# Altium schematic sheet Power.SchDoc
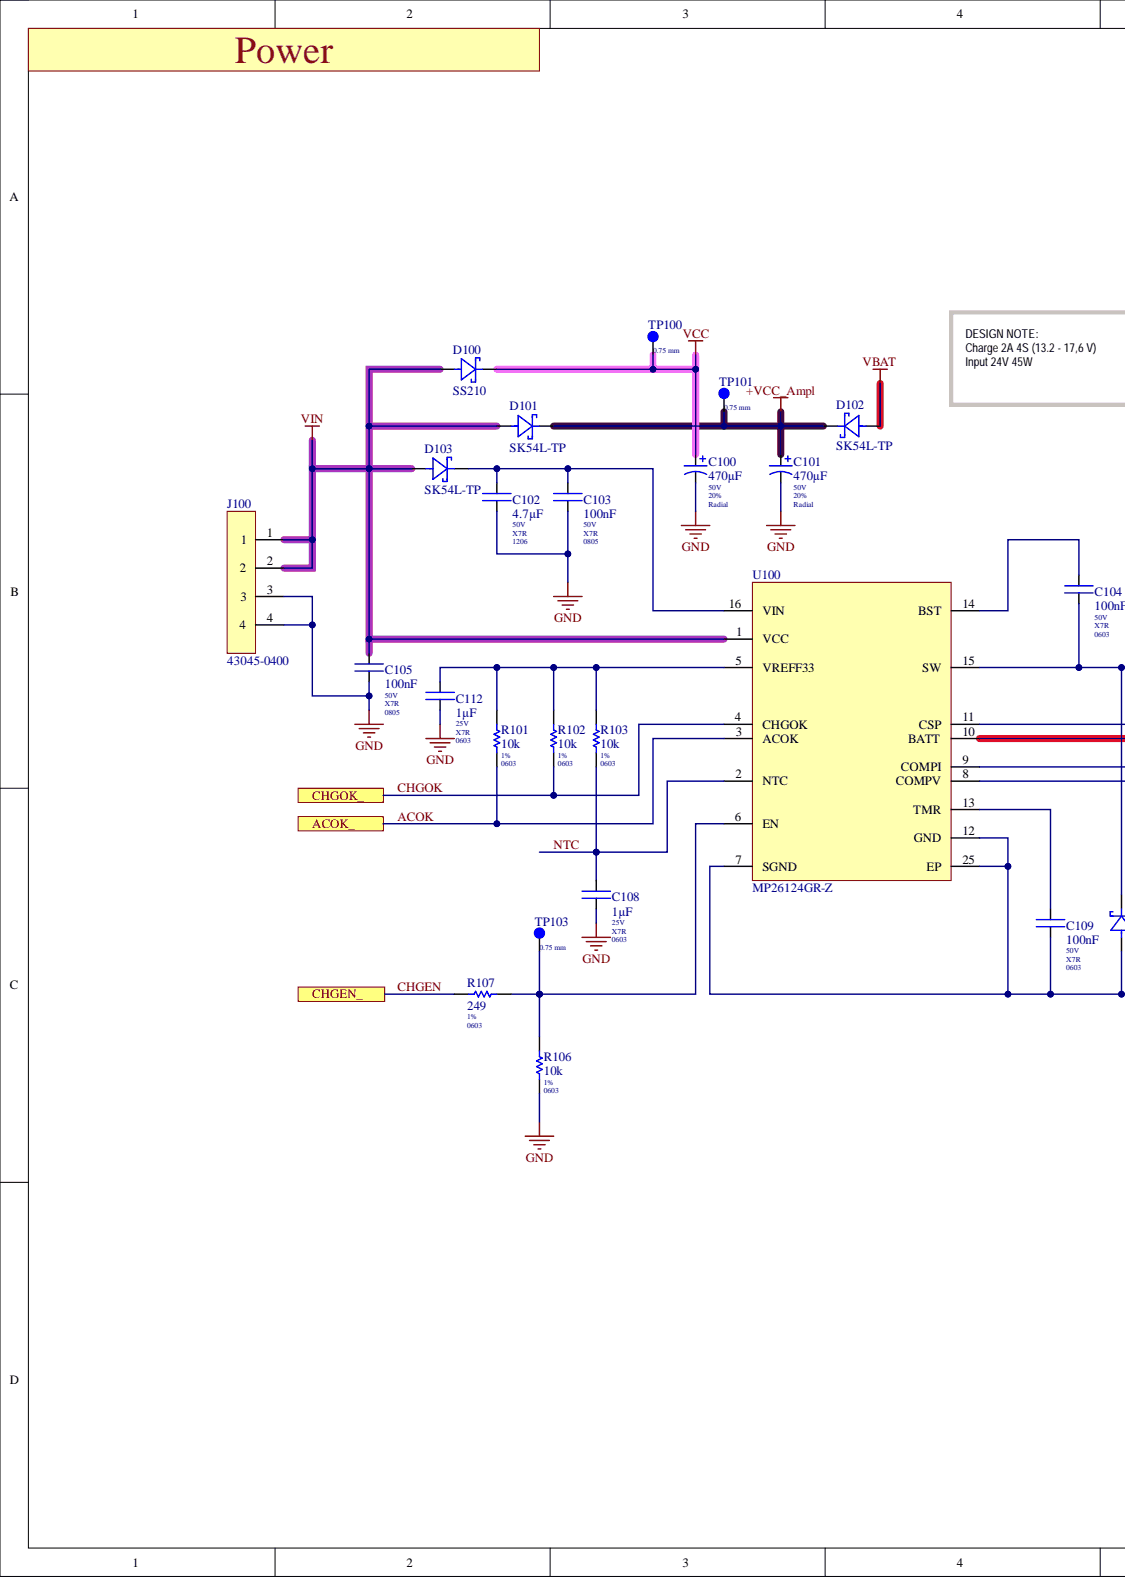
[diagram p0: Power.SchDoc, left]
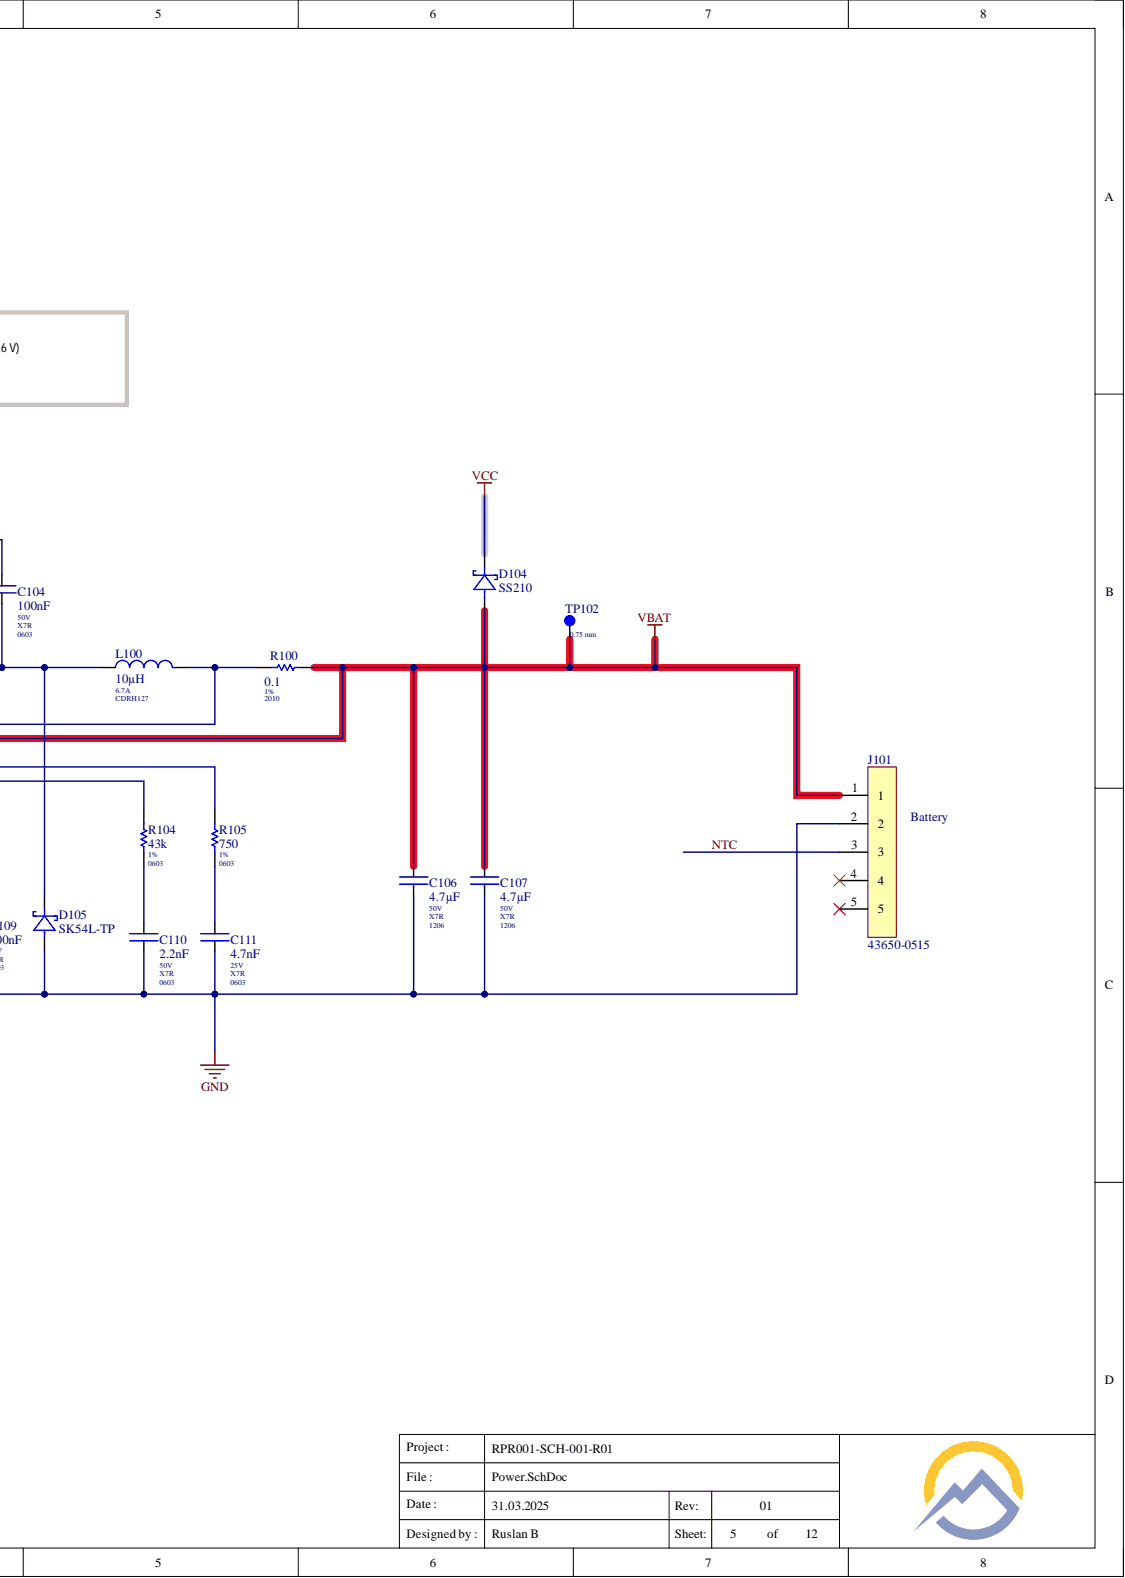
[diagram p1: Power.SchDoc, right]

## Parts
C100  value 470µF  Case/Package: Radial  Tolerance: 20%  Voltage Rating: 50V  [EMVA500ARA471MKG5S]  (hidden comment: EMVA500ARA471MKG5S)
C101  value 470µF  Case/Package: Radial  Tolerance: 20%  Voltage Rating: 50V  [EMVA500ARA471MKG5S]  (hidden comment: EMVA500ARA471MKG5S)
C102  value 4.7µF  Case/Package: 1206  Dielectric: X7R  Voltage Rating: 50V  [B_Cap_1206_KEM]  (hidden comment: GRM31CR71H475KA12L)
C103  value 100nF  Case/Package: 0805  Dielectric: X7R  Voltage Rating: 50V  [B_Cap_0805_KEM]  (hidden comment: CC0805KRX7R9BB104)
C104  value 100nF  Case/Package: 0603  Dielectric: X7R  Voltage Rating: 50V  [B_Cap_0603_KEM]  (hidden comment: GCM188R71H104KA57D)
C105  value 100nF  Case/Package: 0805  Dielectric: X7R  Voltage Rating: 50V  [B_Cap_0805_KEM]  (hidden comment: CC0805KRX7R9BB104)
C106  value 4.7µF  Case/Package: 1206  Dielectric: X7R  Voltage Rating: 50V  [B_Cap_1206_KEM]  (hidden comment: GRM31CR71H475KA12L)
C107  value 4.7µF  Case/Package: 1206  Dielectric: X7R  Voltage Rating: 50V  [B_Cap_1206_KEM]  (hidden comment: GRM31CR71H475KA12L)
C108  value 1µF  Case/Package: 0603  Dielectric: X7R  Voltage Rating: 25V  [B_Cap_0603_KEM]  (hidden comment: CL10B105KA8NNNC)
C109  value 100nF  Case/Package: 0603  Dielectric: X7R  Voltage Rating: 50V  [B_Cap_0603_KEM]  (hidden comment: GCM188R71H104KA57D)
C110  value 2.2nF  Case/Package: 0603  Dielectric: X7R  Voltage Rating: 50V  [B_Cap_0603_KEM]  (hidden comment: CC0603KRX7R9BB222)
C111  value 4.7nF  Case/Package: 0603  Dielectric: X7R  Voltage Rating: 25V  [B_Cap_0603_KEM]  (hidden comment: AC0603KRX7R8BB472)
C112  value 1µF  Dielectric: X7R  Voltage Rating: 25V  [B_Cap_0603_KEM]  (hidden comment: CL10B105KA8NNNC)
D100  SS210  [DO-214AA, SMB]
D101  SK54L-TP  [DO-214AB, SMC]
D102  SK54L-TP  [DO-214AB, SMC]
D103  SK54L-TP  [DO-214AB, SMC]
D104  SS210  [DO-214AA, SMB]
D105  SK54L-TP  [DO-214AB, SMC]
J100  43045-0400  [43045-0400-Footprint-1]
J101  43650-0515  [FP-43650-0515-MFG]
L100  value 10µH  Case/Package: CDRH127  Current Rating: 6.7A  [DR127]  (hidden comment: CDRH127/LDNP-100MC)
R100  value 0.1  Case/Package: 2010  Tolerance: 1%  [Res_2010_YAG_R]  (hidden comment: PE2010FKE070R1L)
R101  value 10k  Case/Package: 0603  Tolerance: 1%  [Res_0603_YAG]  (hidden comment: RC0603FR-0710KL)
R102  value 10k  Case/Package: 0603  Tolerance: 1%  [Res_0603_YAG]  (hidden comment: RC0603FR-0710KL)
R103  value 10k  Case/Package: 0603  Tolerance: 1%  [Res_0603_YAG]  (hidden comment: RC0603FR-0710KL)
R104  value 43k  Case/Package: 0603  Tolerance: 1%  [Res_0603_YAG]  (hidden comment: RC0603FR-0743KL)
R105  value 750  Case/Package: 0603  Tolerance: 1%  [Res_0603_YAG]  (hidden comment: RC0603FR-07750RL)
R106  value 10k  Case/Package: 0603  Tolerance: 1%  [Res_0603_YAG]  (hidden comment: RC0603FR-0710KL)
R107  value 249  Case/Package: 0603  Tolerance: 1%  [Res_0603_YAG]  (hidden comment: RC0603FR-07249RL)
TP100  0.75 mm  [0.75mm]  (no BOM)
TP101  0.75 mm  [0.75mm]  (no BOM)
TP102  0.75 mm  [0.75mm]  (no BOM)
TP103  0.75 mm  [0.75mm]  (no BOM)
U100  MP26124GR-Z  [16-VQFN]

## Ports
ACOK_, CHGEN_, CHGOK_

## Nets
+VCC_Ampl: C101.1 D101.1 D102.1 TP101.1
GND: C100.2 C101.2 C102.1 C103.1 C105.1 C106.1 C107.1 C108.1 C109.1 C110.1 C111.1 C112.1 D105.2 J100.3 J100.4 J101.2 R106.1 U100.7(SGND) U100.12(GND) U100.25(EP)
VBAT: C106.2 C107.2 D102.2 D104.2 J101.1 R100.2 TP102.1 U100.10(BATT)
VCC: C100.1 D100.1 D104.1 TP100.1
VIN: C105.2 D100.2 D101.2 D103.2 J100.1 J100.2 U100.1(VCC)
ACOK: R101.1 U100.3(ACOK) ; port ACOK_
CHGEN: R107.1 ; port CHGEN_
CHGOK: R102.1 U100.4(CHGOK) ; port CHGOK_
NTC: C108.2 J101.3 R103.1 U100.2(NTC)
NetC102_2: C102.2 C103.2 D103.1 U100.16(VIN)
NetC104_1: C104.1 D105.1 L100.1 U100.15(SW)
NetC104_2: C104.2 U100.14(BST)
NetC109_2: C109.2 U100.13(TMR)
NetC110_2: C110.2 R104.1
NetC111_2: C111.2 R105.2
NetC112_2: C112.2 R101.2 R102.2 R103.2 U100.5(VREFF33)
NetL100_2: L100.2 R100.1 U100.11(CSP)
NetR104_2: R104.2 U100.8(COMPV)
NetR105_1: R105.1 U100.9(COMPI)
NetR106_2: R106.2 R107.2 TP103.1 U100.6(EN)

Not connected: J101 4 5

## Library data of the parts
C100 C101 = CMP-CAP-ALU-00015-7: CAP ALUM 470UF 20% 50V SMD; Manufacturer 1: United Chemi-Con; Ripple Current: 740mA; Component Kind: Standard; ComponentLink1Description: Datasheet; ComponentLink1URL: https://www.chemi-con.co.jp/products/relatedfiles/capacitor/catalog/MVARA-e.PDF; Library Name: <Company> Server; Manufacturer Part Number 1: EMVA500ARA471MKG5S; Mount: Surface Mount
C102 C106 C107 = CMP-CAP-CER-00205-11: CAP CER 4.7UF 50V X7R 1206; Manufacturer 1: Murata; Tolerance: 10%; Component Kind: Standard; ComponentLink1Description: Datasheet; ComponentLink1URL: https://www.murata.com/~/media/webrenewal/support/library/catalog/products/capacitor/mlcc/c02e.ashx?la=en-us; Library Name: <Company> Server; Manufacturer Part Number 1: GRM31CR71H475KA12L
C103 C105 = CMP-CAP-CER-00029-14: Multilayer Ceramic Capacitor, 0.1 uF, 50 V, ï¿½ 10%, X7R, 0805 [2012 Metric]; Manufacturer 1: YAGEO; Component Kind: Standard; Library Name: <Company> Server; Manufacturer Part Number 1: CC0805KRX7R9BB104; Tolerance: 10%
C104 C109 = CMP-CAP-CER-00027-13: CAP CER 0.1UF 50V X7R 0603; Manufacturer 1: Murata; Tolerance: ±10%; Component Kind: Standard; ComponentLink1Description: Datasheet; ComponentLink1URL: https://search.murata.co.jp/Ceramy/image/img/A01X/G101/ENG/GCM188R71H104KA57-01.pdf; Library Name: <Company> Server; Manufacturer Part Number 1: GCM188R71H104KA57D
C108 C112 = CMP-CAP-CER-00132-13: CL10B105KA8NNNC Samsung Ceramic Capacitor MLCC 1uF10% 25V X7R SMD 0603 (1608 Metric) RoHS; Manufacturer 1: Samsung Electro-Mechanics; Tolerance: 10%; Component Kind: Standard; Library Name: <Company> Server; Manufacturer Part Number 1: CL10B105KA8NNNC
  C112: Case/Package: 0603
C110 = CMP-CAP-CER-00296-1: Multilayer Ceramic Capacitor, 2200 pF, 50 V, ï¿½ 10%, X7R, 0603 [1608 Metric]; Manufacturer 1: Yageo; Component Kind: Standard; Library Name: <Company> Server; Manufacturer Part Number 1: CC0603KRX7R9BB222; Tolerance: 10%
C111 = CMP-CAP-CER-00215-11: CAP CER 4700PF 25V X7R 0603; Manufacturer 1: Yageo; Tolerance: ±10%; Component Kind: Standard; ComponentLink1Description: Datasheet; ComponentLink1URL: https://www.yageo.com/upload/media/product/productsearch/datasheet/mlcc/UPY-AC_NP0X7R_6.3V-to-1KV_14.pdf; Library Name: <Company> Server; Manufacturer Part Number 1: AC0603KRX7R8BB472
D100 D104 = CMP-DIO-SCH-00037-8: DIODE SCHOTTKY 100V 2A SMA; Manufacturer 1: Taiwan Semiconductor; Forward Voltage: 850mV; Max Reverse Current: 100µA; Average Rectified Current: 2A; Case/Package: DO-214AA; Component Kind: Standard; ComponentLink1Description: Datasheet; ComponentLink1URL: http://www.smc-diodes.com/propdf/SS22A%20THRU%20SS225A%20N1816%20REV.A.pdf; Forward Current: 2A; Library Name: <Company> Server; Manufacturer Part Number 1: SS210; Max Reverse Voltage (DC): 100V
D101-D103 D105 = CMP-DIO-SCH-00038-7: DIODE SCHOTTKY 40V 5A DO214AB; Manufacturer 1: MCC; Forward Voltage: 550mV; Max Reverse Current: 1mA; Average Rectified Current: 5A; Case/Package: DO-214AB; Component Kind: Standard; Forward Current: 5A; Library Name: <Company> Server; Manufacturer Part Number 1: SK54L-TP; Max Reverse Voltage (DC): 40V
J100 = CMP-CON-PCON-00000-3: Header 2 Row Right-Angle Snap-In Lock 4Pin Tin Plate SMT/Glow Wire Compatible; Manufacturer 1: Molex; Current Rating: 8A; Gender: Male; Number of Ports: 4; Voltage Rating: 600V; Component Kind: Standard; Library Name: <Company> Server; Manufacturer Part Number 1: 43045-0400; Number of Pins: 4; Orientation: Right Angle
J101 = CMP-CON-PCON-00009-2: MOLEX 43650-0515 Header Single Row 180° 5p Tin Plating PCB Peg, GW Compatible; Manufacturer Part Number 1: 0436500515; Current Rating: 5A; Gender: Male; Insulation Resistance: 1G; Voltage Rating: 600V; Component Kind: Standard; Library Name: <Company> Server; Manufacturer 1: Molex; Number of Pins: 5; Orientation: Vertical
L100 = CMP-IND-00137-5: Inductor Power Shielded Drum Core 10uH 20% 100KHz Ferrite 6.7A 19.5mOhm DCR T/R; Manufacturer 1: Sumida; DC Resistance (DCR): 19.5m; Component Kind: Standard; Library Name: <Company> Server; Manufacturer Part Number 1: CDRH127/LDNP-100MC; Self Resonant Frequency: 100kHz; Shielding: Shielded; Tolerance: 20%
R100 = CMP-RES-SMD-00571-2: Current Sensor-Low TCR Chip Resistor Automotive Grade Metal Foil 2010 100mOhm 1% Emboss T/R; Manufacturer 1: YAGEO; Power Rating: 1W; Component Kind: Standard; Library Name: <Company> Server; Manufacturer Part Number 1: PE2010FKE070R1L
R101-R103 R106 = CMP-RES-SMD-00183-9: RES SMD 10K OHM 1% 1/10W 0603; Manufacturer 1: Yageo; Temperature Coefficient: ±100ppm/℃; Component Kind: Standard; ComponentLink1Description: Datasheet; ComponentLink1URL: https://www.yageo.com/upload/media/product/productsearch/datasheet/rchip/PYu-RC_Group_51_RoHS_L_10.pdf; Library Name: <Company> Server; Manufacturer Part Number 1: RC0603FR-0710KL
R104 = CMP-RES-SMD-00342-9: RES SMD 43K OHM 1% 1/10W 0603; Manufacturer 1: Yageo; Temperature Coefficient: ±100ppm/℃; Component Kind: Standard; ComponentLink1Description: Datasheet; ComponentLink1URL: https://www.yageo.com/upload/media/product/productsearch/datasheet/rchip/PYu-RC_Group_51_RoHS_L_11.pdf; Library Name: <Company> Server; Manufacturer Part Number 1: RC0603FR-0743KL
R105 = CMP-RES-SMD-00572-1: SMD Chip Resistor, 750 Ohm, ï¿½ 1%, 100 mW, 0603 [1608 Metric], Thick Film, General Purpose; Manufacturer 1: Yageo; Power Rating: 100mW; Temperature Coefficient: 100ppm/°C; Voltage Rating: 50V; Component Kind: Standard; Library Name: <Company> Server; Manufacturer Part Number 1: RC0603FR-07750RL
R107 = CMP-RES-SMD-00474-3: Res Thick Film 0603 249 Ohm 1% 0.1W(1/10W) ±100ppm/°C Pad SMD T/R; Manufacturer 1: Yageo; Power Rating: 100mW; Voltage Rating: 50V; Component Kind: Standard; Library Name: <Company> Server; Manufacturer Part Number 1: RC0603FR-07249RL; Temperature Coefficient: 100ppm/°C
TP100-TP103 = CMP-MF-TP-00003-4: Test point 0.75 mm; Library Name: <Company> Server; Size: 0.75 mm
U100 = CMP-POW-BATM-00006-5: 24V Input 2A 600kHz 4S Cell Switching Li-Ion Battery Charger; Manufacturer 1: Monolithic Power Systems; Number of Cells: 4; Case/Package: 16-VQFN; Component Kind: Standard; Library Name: <Company> Server; Manufacturer Part Number 1: MP26124GR-Z; Max Charge Current: 2A; Max Supply Voltage: 24V; Output Current: 2A
